# Altium schematic sheet Rpi.SchDoc
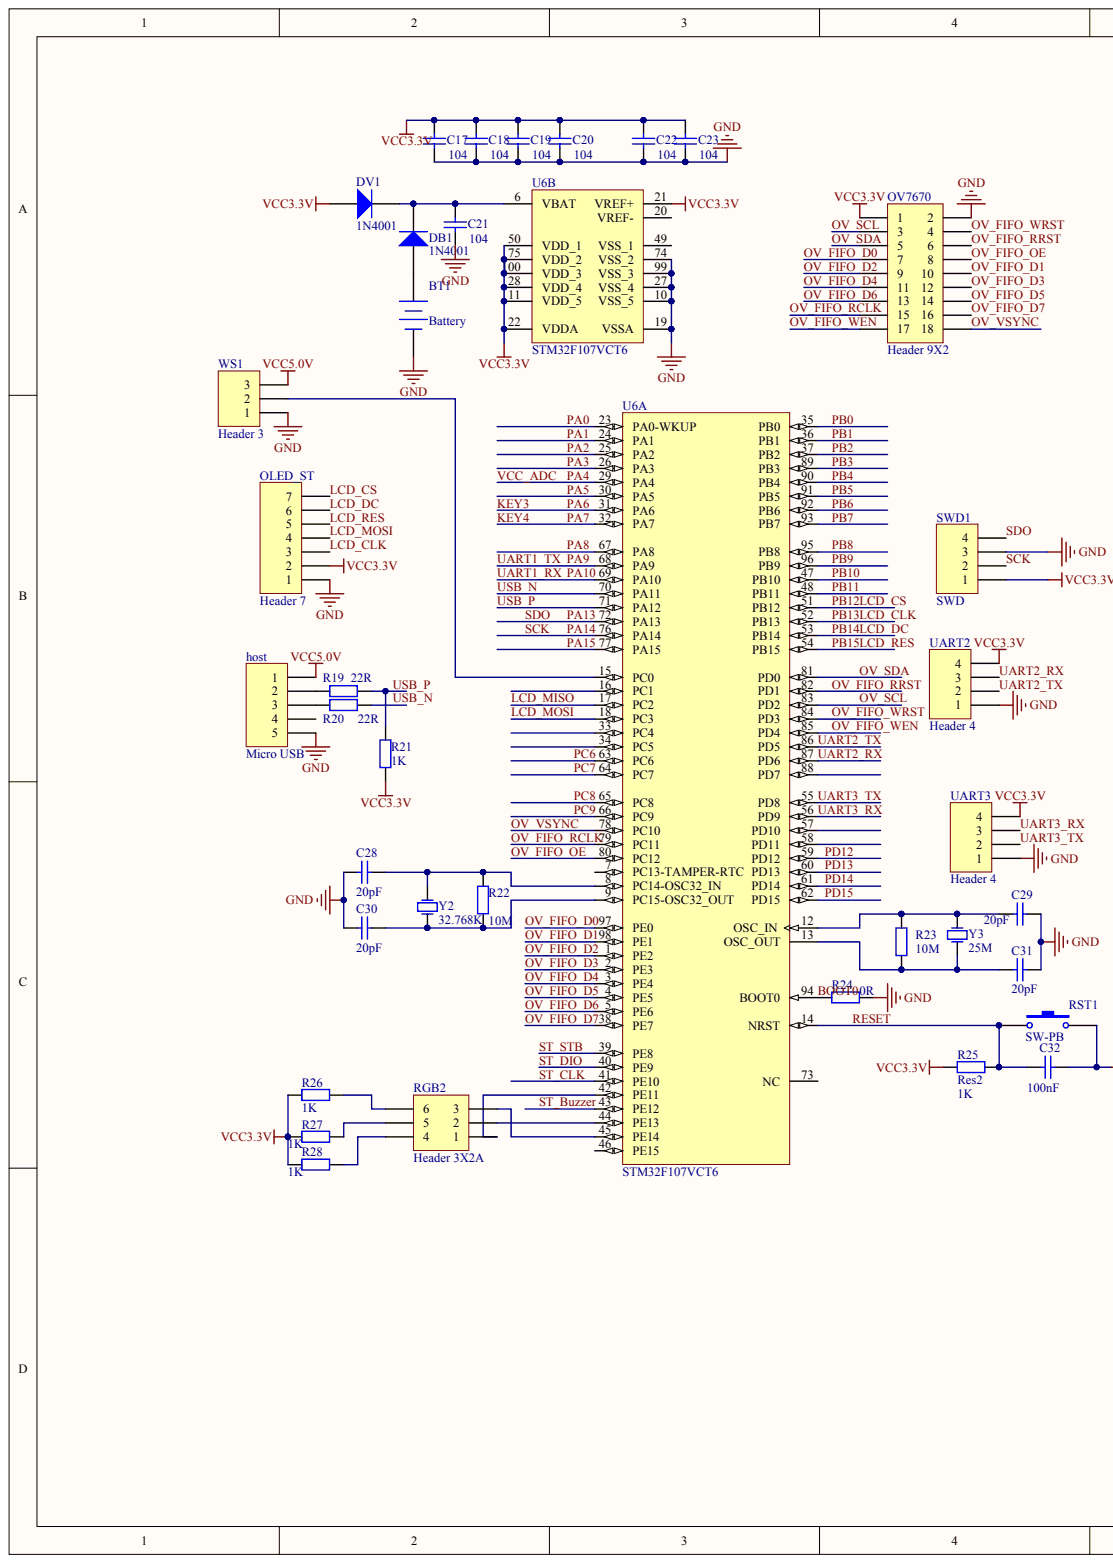
[diagram p0: Rpi.SchDoc, left]
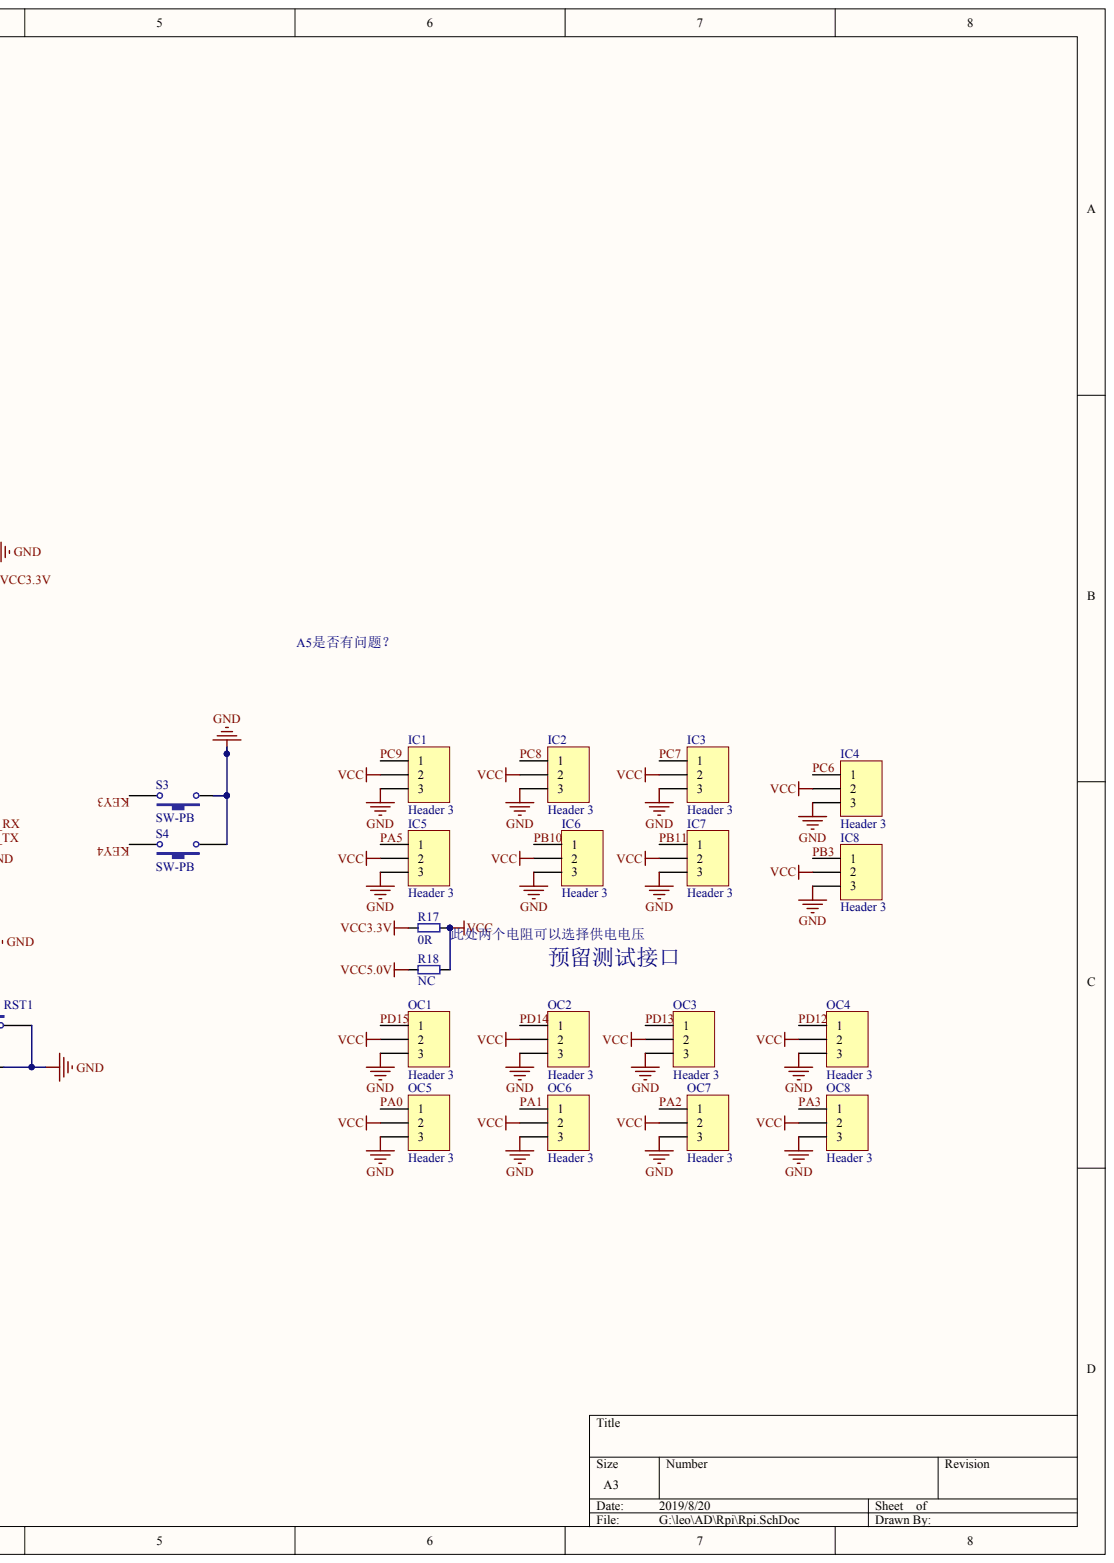
[diagram p1: Rpi.SchDoc, right]

## Parts
BT1  Battery  [CR1220]
C17  value 104  [0603C]  (hidden comment: Cap)
C18  value 104  [0603C]  (hidden comment: Cap)
C19  value 104  [0603C]  (hidden comment: Cap)
C20  value 104  [0603C]  (hidden comment: Cap)
C21  value 104  [0603C]  (hidden comment: Cap)
C22  value 104  [0603C]  (hidden comment: Cap)
C23  value 104  [0603C]  (hidden comment: Cap)
C28  value 20pF  [0603c]  (hidden comment: Cap)
C29  value 20pF  [0603c]  (hidden comment: Cap)
C30  value 20pF  [0603c]  (hidden comment: Cap)
C31  value 20pF  [0603c]  (hidden comment: Cap)
C32  value 100nF  [0603C]  (hidden comment: Cap)
DB1  Type: 1N4001  [0603r]  (hidden comment: Diode)
DV1  Type: 1N4001  [0603r]  (hidden comment: Diode)
host  Micro USB  [Micro USB]
IC1  Header 3  [HDR1X3]
IC2  Header 3  [HDR1X3]
IC3  Header 3  [HDR1X3]
IC4  Header 3  [HDR1X3]
IC5  Header 3  [HDR1X3]
IC6  Header 3  [HDR1X3]
IC7  Header 3  [HDR1X3]
IC8  Header 3  [HDR1X3]
OC1  Header 3  [HDR1X3]
OC2  Header 3  [HDR1X3]
OC3  Header 3  [HDR1X3]
OC4  Header 3  [HDR1X3]
OC5  Header 3  [HDR1X3]
OC6  Header 3  [HDR1X3]
OC7  Header 3  [HDR1X3]
OC8  Header 3  [HDR1X3]
OLED_ST  Header 7  [HDR1X7]
OV7670  Header 9X2  [HDR2X9]
R17  0R  [0805R]
R18  NC  [0805R]
R19  22R  [0603R]
R20  22R  [0603R]
R21  1K  [0603R]
R22  value 10M  [0603R]
R23  value 10M  [0603R]
R24  value 0R  [0603R]  (hidden comment: Res2)
R25  Res2  value 1K  [0603R]
R26  1K  [0603R]
R27  1K  [0603R]
R28  1K  [0603R]
RGB2  Header 3X2A  [RGB5050]
RST1  SW-PB  [SW_PB2_A1]
S3  SW-PB  [SW_PB2_A1]
S4  SW-PB  [SW_PB2_A1]
SWD1  SWD  [HDR1X4]
U6  STM32F107VCT6  [STM-LQFP100_N]  (parts A,B)
UART2  Header 4  [HDR1X4]
UART3  Header 4  [HDR1X4]
WS1  Header 3  [HDR1X3]
Y2  32.768K  [X-TC15]
Y3  25M  [XTAL-HC-49/S]

## Nets
GND: BT1.2 C17.1 C18.1 C19.1 C20.1 C21.1 C22.1 C23.1 C28.2 C29.2 C30.2 C31.2 C32.2 host.5 IC1.3 IC2.3 IC3.3 IC4.3 IC5.3 IC6.3 IC7.3 IC8.3 OC1.3 OC2.3 OC3.3 OC4.3 OC5.3 OC6.3 OC7.3 OC8.3 OLED_ST.1 OV7670.2 R24.2 RST1.2 S3.1 S4.1 SWD1.3 U6.10(VSS_5) U6.19(VSSA) U6.27(VSS_4) U6.74(VSS_2) U6.99(VSS_3) UART2.1 UART3.1 WS1.1
VCC: IC1.2 IC2.2 IC3.2 IC4.2 IC5.2 IC6.2 IC7.2 IC8.2 OC1.2 OC2.2 OC3.2 OC4.2 OC5.2 OC6.2 OC7.2 OC8.2 R17.2 R18.2
VCC3.3V: C17.2 C18.2 C19.2 C20.2 C22.2 C23.2 DV1.1 OLED_ST.2 OV7670.1 R17.1 R21.1 R25.1 R26.1 R27.1 R28.1 SWD1.1 U6.11(VDD_5) U6.21(VREF+) U6.22(VDDA) U6.28(VDD_4) U6.50(VDD_1) U6.75(VDD_2) U6.100(VDD_3) UART2.4 UART3.4
VCC5.0V: host.1 R18.1 WS1.3
BOOT0: R24.1 U6.94(BOOT0)
KEY3: S3.2 U6.31(PA6)
KEY4: S4.2 U6.32(PA7)
LCD_CLK: OLED_ST.3 U6.52(PB13)
LCD_CS: OLED_ST.7 U6.51(PB12)
LCD_DC: OLED_ST.6 U6.53(PB14)
LCD_MISO: U6.17(PC2)
LCD_MOSI: OLED_ST.4 U6.18(PC3)
LCD_RES: OLED_ST.5 U6.54(PB15)
OV_FIFO_D0: OV7670.7 U6.97(PE0)
OV_FIFO_D1: OV7670.10 U6.98(PE1)
OV_FIFO_D2: OV7670.9 U6.1(PE2)
OV_FIFO_D3: OV7670.12 U6.2(PE3)
OV_FIFO_D4: OV7670.11 U6.3(PE4)
OV_FIFO_D5: OV7670.14 U6.4(PE5)
OV_FIFO_D6: OV7670.13 U6.5(PE6)
OV_FIFO_D7: OV7670.16 U6.38(PE7)
OV_FIFO_OE: OV7670.8 U6.80(PC12)
OV_FIFO_RCLK: OV7670.15 U6.79(PC11)
OV_FIFO_RRST: OV7670.6 U6.82(PD1)
OV_FIFO_WEN: OV7670.17 U6.85(PD4)
OV_FIFO_WRST: OV7670.4 U6.84(PD3)
OV_SCL: OV7670.3 U6.83(PD2)
OV_SDA: OV7670.5 U6.81(PD0)
OV_VSYNC: OV7670.18 U6.78(PC10)
PA0: OC5.1 U6.23(PA0-WKUP)
PA1: OC6.1 U6.24(PA1)
PA2: OC7.1 U6.25(PA2)
PA3: OC8.1 U6.26(PA3)
PA4: U6.29(PA4)
PA5: IC5.1 U6.30(PA5)
PA8: U6.67(PA8)
PA9: U6.68(PA9)
PA10: U6.69(PA10)
PA13: SWD1.4 U6.72(PA13)
PA14: SWD1.2 U6.76(PA14)
PA15: U6.77(PA15)
PB0: U6.35(PB0)
PB1: U6.36(PB1)
PB2: U6.37(PB2)
PB3: IC8.1 U6.89(PB3)
PB4: U6.90(PB4)
PB5: U6.91(PB5)
PB6: U6.92(PB6)
PB7: U6.93(PB7)
PB8: U6.95(PB8)
PB9: U6.96(PB9)
PB10: IC6.1 U6.47(PB10)
PB11: IC7.1 U6.48(PB11)
PC6: IC4.1 U6.63(PC6)
PC7: IC3.1 U6.64(PC7)
PC8: IC2.1 U6.65(PC8)
PC9: IC1.1 U6.66(PC9)
PD12: OC4.1 U6.59(PD12)
PD13: OC3.1 U6.60(PD13)
PD14: OC2.1 U6.61(PD14)
PD15: OC1.1 U6.62(PD15)
RESET: C32.1 R25.2 RST1.1 U6.14(NRST)
ST_BUZZER: U6.43(PE12)
ST_CLK: U6.41(PE10)
ST_DIO: U6.40(PE9)
ST_STB: U6.39(PE8)
UART2_RX: U6.87(PD6) UART2.3
UART2_TX: U6.86(PD5) UART2.2
UART3_RX: U6.56(PD9) UART3.3
UART3_TX: U6.55(PD8) UART3.2
USB_N: R20.2 U6.70(PA11)
USB_P: R19.2 R21.2 U6.71(PA12)
NetBT1_1: BT1.1 DB1.1
NetC21_2: C21.2 DB1.2 DV1.2 U6.6(VBAT)
NetC28_1: C28.1 R22.1 U6.8(PC14-OSC32_IN) Y2.1
NetC29_1: C29.1 R23.2 U6.12(OSC_IN) Y3.2
NetC30_1: C30.1 R22.2 U6.9(PC15-OSC32_OUT) Y2.2
NetC31_1: C31.1 R23.1 U6.13(OSC_OUT) Y3.1
Nethost_2: host.2 R19.1
Nethost_3: host.3 R20.1
NetR26_2: R26.2 RGB2.6
NetR27_2: R27.2 RGB2.5
NetR28_2: R28.2 RGB2.4
NetRGB2_1: RGB2.1 U6.42(PE11)
NetRGB2_2: RGB2.2 U6.44(PE13)
NetRGB2_3: RGB2.3 U6.45(PE14)
NetU6_15: U6.15(PC0) WS1.2

Not connected: host 4; U6 7(PC13-TAMPER-RTC) 16(PC1) 20(VREF-) 33(PC4) 34(PC5) 46(PE15) 49(VSS_1) 57(PD10) 58(PD11) 73(NC) 88(PD7)

## Library data of the parts
BT1 = Battery: 备份电池; Component Kind: Standard; LatestRevisionDate: 17-Jul-2002; LatestRevisionNote: Re-released for DXP Platform.; PackageReference: BAT-2; Published: 24-Mar-1999; Library Name: fszhang.SchLib; Publisher: Altium Limited
C17-C23 C32 = Cap: Capacitor; Component Kind: Standard; LatestRevisionDate: 17-Jul-2002; LatestRevisionNote: Re-released for DXP Platform.; Library Name: Miscellaneous Devices.IntLib; PackageDescription: Radial Cap, Thru-Hole; 2 Leads; 0.3 in Pin Spacing; PackageReference: RAD-0.3; Published: 8-Jun-2000; Signal Integrity: Cap; Simulation: CAP; Publisher: Altium Limited
C28-C31 = Cap: 8p; Component Kind: Standard; LatestRevisionDate: 17-Jul-2002; LatestRevisionNote: Re-released for DXP Platform.; Library Name: Miscellaneous Devices.IntLib; PackageDescription: Radial Cap, Thru-Hole; 2 Leads; 0.3 in Pin Spacing; PackageReference: RAD-0.3; Published: 8-Jun-2000; Signal Integrity: Cap; Simulation: CAP; Publisher: Altium Limited
DB1 DV1 = Diode: 二极管; Code_JEDEC: DO-214-AB; Component Kind: Standard; LatestRevisionDate: 17-Jul-2002; LatestRevisionNote: Re-released for DXP Platform.; PackageDocument: Sep-1996; PackageReference: SMC; Published: 8-Jun-2000; Library Name: fszhang.SchLib; Publisher: Altium Limited
host = Header 5: Header, 5-Pin; Component Kind: Standard; LatestRevisionDate: 17-Jul-2002; LatestRevisionNote: Re-released for DXP Platform.; Library Name: Miscellaneous Connectors.IntLib; Signal Integrity: Connector; Publisher: Altium Limited
IC1-IC8 OC1-OC8 WS1 = Header 3: Header, 3-Pin; Component Kind: Standard; LatestRevisionDate: 17-Jul-2002; LatestRevisionNote: Re-released for DXP Platform.; Library Name: Miscellaneous Connectors.IntLib; Signal Integrity: Connector; Publisher: Altium Limited
OLED_ST = Header 7: Header, 7-Pin; Component Kind: Standard; LatestRevisionDate: 17-Jul-2002; LatestRevisionNote: Re-released for DXP Platform.; Library Name: Miscellaneous Connectors.IntLib; Signal Integrity: Connector; Publisher: Altium Limited
OV7670 = Header 9X2: Header, 9-Pin, Dual row; Component Kind: Standard; LatestRevisionDate: 17-Jul-2002; LatestRevisionNote: Re-released for DXP Platform.; Library Name: Miscellaneous Connectors.IntLib; Signal Integrity: Connector; Publisher: Altium Limited
R17 R18 = Res_2: Resistor; Component Kind: Standard; Library Name: LZ PcbLib - ALL.SchLib
R19-R28 = Res2: Resistor; Component Kind: Standard; LatestRevisionDate: 17-Jul-2002; LatestRevisionNote: Re-released for DXP Platform.; PackageReference: AXIAL-0.4; Published: 8-Jun-2000; Publisher: Altium Limited
  R22-R25: Library Name: Miscellaneous Devices.IntLib; PackageDescription: Axial Device, Thru-Hole; 2 Leads; 0.4 in Pin Spacing; Signal Integrity: Res; Simulation: RESISTOR
RGB2 = Header 3X2A: Header, 3-Pin, Dual row; Component Kind: Standard; LatestRevisionDate: 17-Jul-2002; LatestRevisionNote: Re-released for DXP Platform.; Library Name: Miscellaneous Connectors.IntLib; Signal Integrity: Connector; Publisher: Altium Limited
RST1 S3 S4 = SW-PB: 微动开关; Component Kind: Standard; LatestRevisionDate: 17-Jul-2002; LatestRevisionNote: Re-released for DXP Platform.; PackageReference: SPST-2; Published: 24-Mar-1999; Library Name: fszhang.SchLib; Publisher: Altium Limited
SWD1 UART2 UART3 = Header 4: Header, 4-Pin; Component Kind: Standard; LatestRevisionDate: 17-Jul-2002; LatestRevisionNote: Re-released for DXP Platform.; Library Name: Miscellaneous Connectors.IntLib; Signal Integrity: Connector; Publisher: Altium Limited
U6 = STM32F107VCT6: ARM Cortex-M3 32-bit MCU, 256 KB Flash, 64 KB Internal RAM, 80 I/Os, 100-pin LQFP, -40 to 85 degC, Tray; A/D Converter: 2x12-bit (16 Channel); D/A Converter: 2x12-bit (2 Channel); FLASH Size (Prog) (kB): 256; I/Os (High Current): 80; Internal RAM Size (kB): 64; Operating Frequency(F) (Processor speed) (MHz): 72; Supply Voltage(Vcc) min (V): 2; Component Kind: Standard; ComponentLink1Description: Manufacturer URL; ComponentLink1URL: http://www.st.com/web/en/home.html; ComponentLink2Description: Datasheet; ComponentLink2URL: http://www.st.com/st-web-ui/static/active/en/resource/technical/document/datasheet/CD00220364.pdf; ComponentLink3Description: Package Specification; ComponentLink3URL: http://www.st.com/st-web-ui/static/active/en/resource/technical/document/data_brief/DM00071938.pdf; Core: ARM Cortex-M3; DatasheetVersion: Rev. 6; Library Name: STMicroelectronics STM32 F1.IntLib; Mounting Technology: Surface Mount; PackageDescription: 100-Pin Low Profile Quad Flat Package, 14 x 14 mm Body, 0.5 mm Pitch; PackageReference: LQFP100; PackageVersion: Rev. 1, 06/2013; Packing: Tray; PartNumber: STM32F107VCT6; Supplier 1: Digi-Key; Supplier 2: Farnell; Supplier 3: Newark; Supplier 4: Newark; Supplier 5: Mouser; Supplier Part Number 1: 497-8913-ND; Supplier Part Number 2: 1737141; Supplier Part Number 3: 26R3021; Supplier Part Number 4: 25R9446; Supplier Part Number 5: 511-STM32F107VCT6
Y2 Y3 = XTAL: Crystal Oscillator; Component Kind: Standard; Library Name: LZ PcbLib - ALL.SchLib
